# Altium schematic sheet [10]-MODEM_CONNECTORS.SchDoc([10]-MODEM_CONNECTORS)
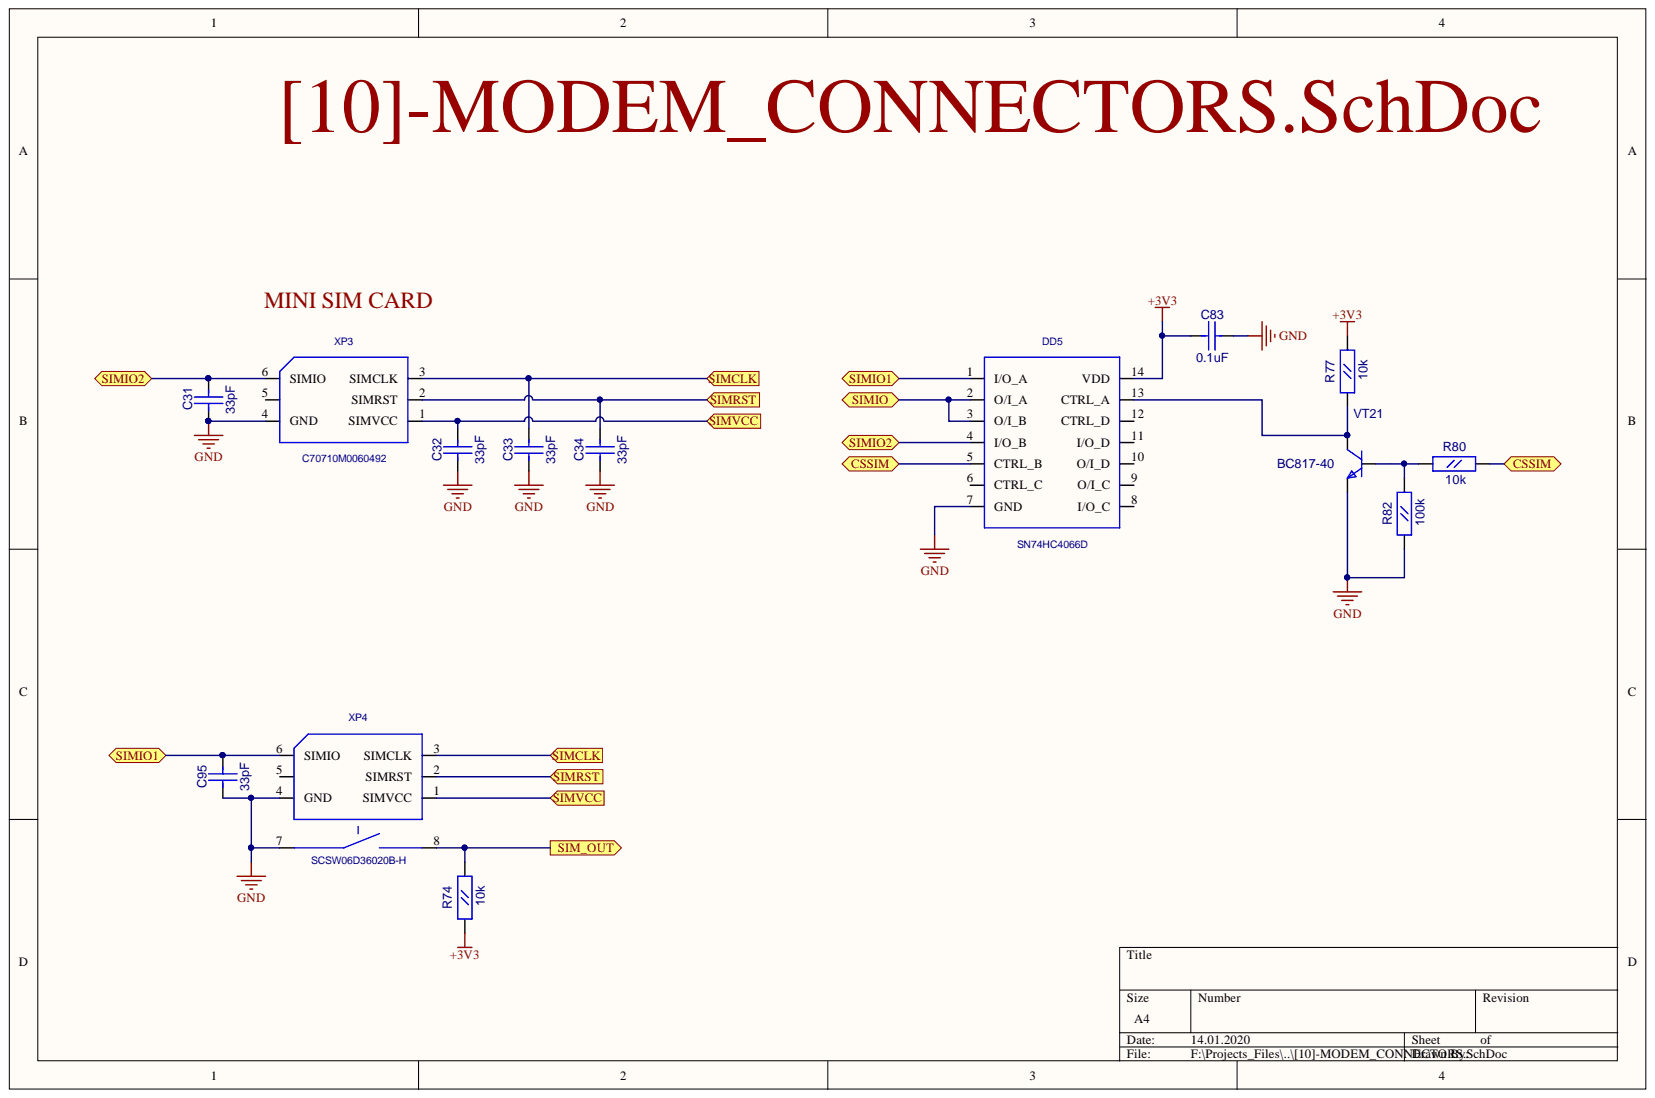
[diagram p0: [10]-MODEM_CONNECTORS.SchDoc]

## Parts
C31  33pF  [CC0603]
C32  33pF  [CC0603]
C33  33pF  [CC0603]
C34  33pF  [CC0603]
C83  0.1uF  [CC0603]
C95  33pF  [CC0603]
DD5  SN74HC4066D  [SO14]
R74  10k  [RC0603]
R77  10k  [RC0603]
R80  10k  [RC0603]
R82  100k  [RC0603]
VT21  BC817-40  [SOT-23(123)]
XP3  C70710M0060492  [SCVW06A25020]
XP4  SCSW06D36020B-H  [SCSW06D36020B-H]

## Ports
CSSIM, SIM_OUT, SIMCLK, SIMIO, SIMIO1, SIMIO2, SIMRST, SIMVCC

## Nets
+3V3: C83.1 DD5.14(VDD) R74.1 R77.2
GND: C31.1 C32.1 C33.1 C34.1 C83.2 C95.1 DD5.7(GND) R82.1 VT21.2 XP3.4(GND) XP4.4(GND) XP4.7
CSSIM: DD5.5(CTRL_B) R80.2 ; port CSSIM
SIM_OUT: R74.2 XP4.8 ; port SIM_OUT
SIMCLK: C33.2 XP3.3(SIMCLK) XP4.3(SIMCLK) ; port SIMCLK
SIMIO: DD5.2(O/I_A) DD5.3(O/I_B) ; port SIMIO
SIMIO1: C95.2 DD5.1(I/O_A) XP4.6(SIMIO) ; port SIMIO1
SIMIO2: C31.2 DD5.4(I/O_B) XP3.6(SIMIO) ; port SIMIO2
SIMRST: C34.2 XP3.2(SIMRST) XP4.2(SIMRST) ; port SIMRST
SIMVCC: C32.2 XP3.1(SIMVCC) XP4.1(SIMVCC) ; port SIMVCC
NetDD5_13: DD5.13(CTRL_A) R77.1 VT21.3
NetR80_1: R80.1 R82.2 VT21.1

Not connected: DD5 6(CTRL_C) 8(I/O_C) 9(O/I_C) 10(O/I_D) 11(I/O_D) 12(CTRL_D); XP3 5; XP4 5

## Library data of the parts
C31-C34 C83 C95 = CC0603: Description: CC0603; Component Kind: Standard
  C31-C34 C95: Value: 33pF; Library Name: gprs_modem_2.SchLib
  C83: Value: 0.1uF; Library Name: fizik_lib.SchLib
R74 R77 R80 R82 = RC0603: Description: RC0603; Component Kind: Standard
  R74 R77 R80: Value: 10k
  R82: Value: 100k
  R74: Library Name: fizik_lib (1).SchLib
  R77 R80 R82: Library Name: fizik_lib.SchLib
VT21 = BC846BLT1: NPN 500ma transistor; ComponentField7: Published 29-Sep-2000; Value: BC817-40; Simulation: BC846BLT1; OriginalName: BC846BLT1; Component Kind: Standard; Library Name: fizik_lib.SchLib
XP3 = SCV-W06A25-020: Description: SCV-W06A25-020; Component Kind: Standard; Library Name: gprs_modem_2.SchLib
DD5 = SN74HC4066D: Description: SN74HC4066D; Component Kind: Standard; Library Name: fizik_lib.SchLib
XP4 = SCSW06D36020B-H: Description: SCSW06D36020B-H; Component Kind: Standard; Library Name: fizik_lib (1).SchLib
